# Altium schematic sheet ETX_Rev2_main.SchDoc(ETX_Rev2_main)
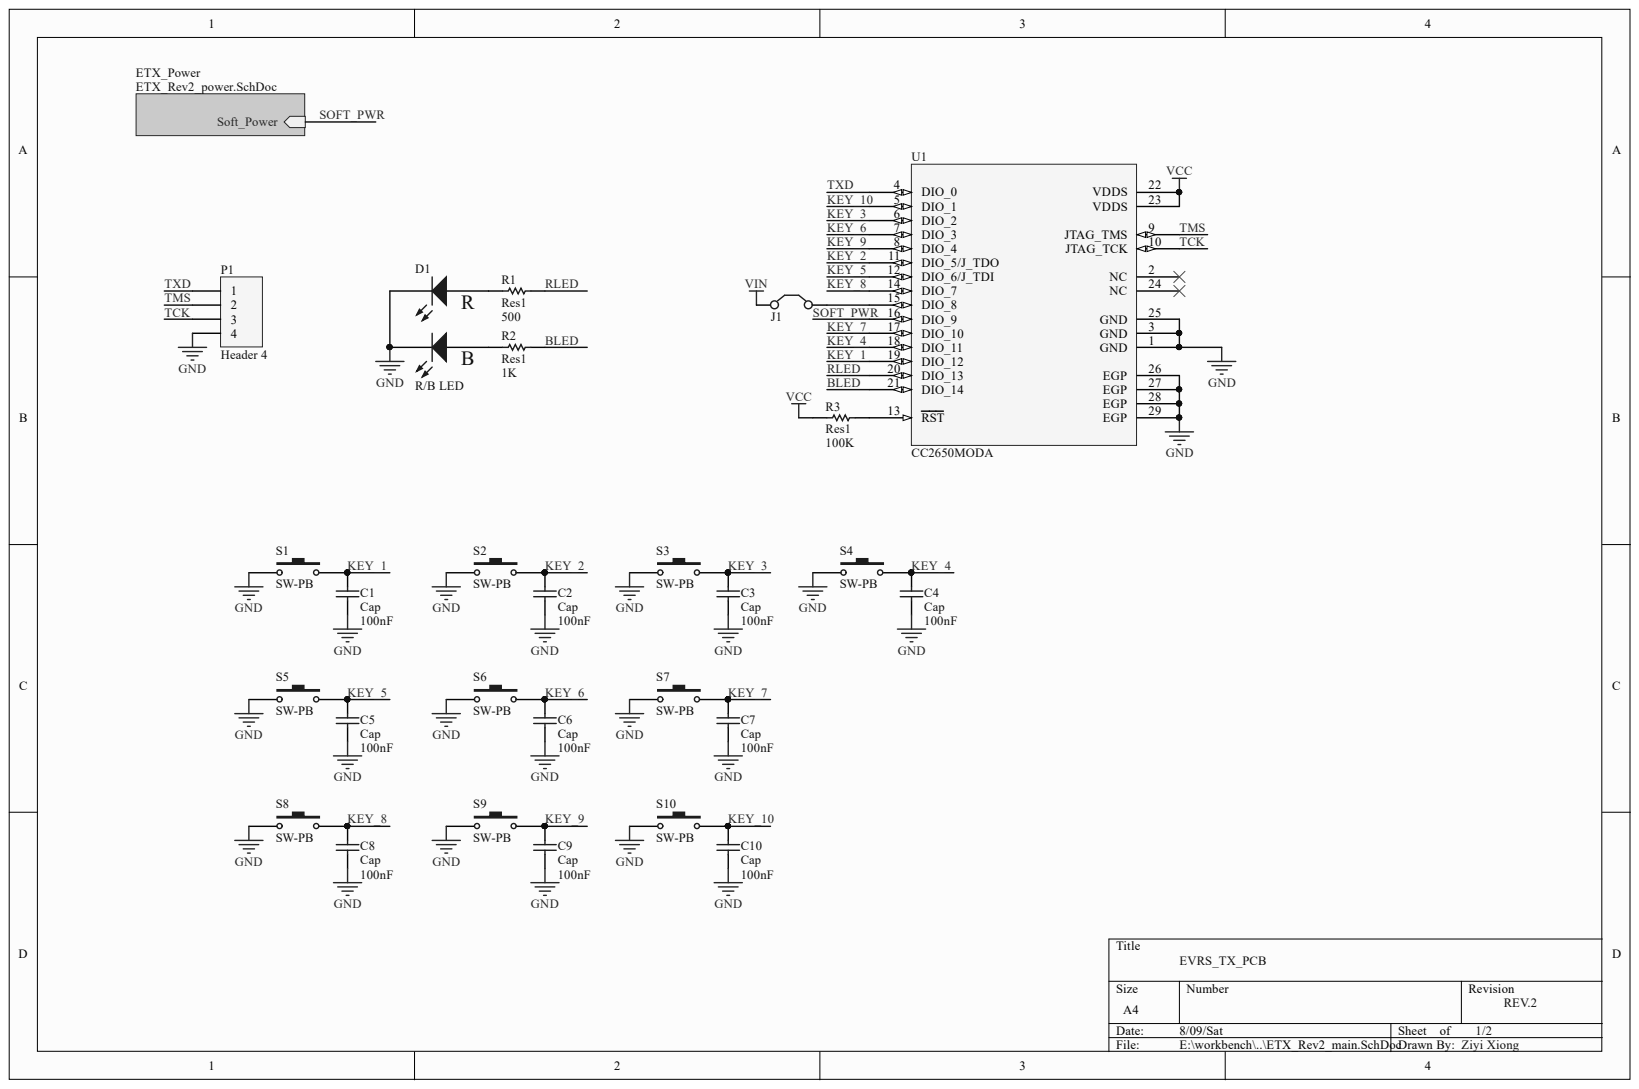
[diagram p0: ETX_Rev2_main.SchDoc]

## Parts
C1  Cap  value 100nF  [0805_NP]
C2  Cap  value 100nF  [0805_NP]
C3  Cap  value 100nF  [0805_NP]
C4  Cap  value 100nF  [0805_NP]
C5  Cap  value 100nF  [0805_NP]
C6  Cap  value 100nF  [0805_NP]
C7  Cap  value 100nF  [0805_NP]
C8  Cap  value 100nF  [0805_NP]
C9  Cap  value 100nF  [0805_NP]
C10  Cap  value 100nF  [0805_NP]
D1  R/B LED  [BILED]
J1  Jumper  [0805_NP]  (value not drawn)
P1  Header 4  [SOCKET 4POS]
R1  Res1  value 500  [0805_NP]
R2  Res1  value 1K  [0805_NP]
R3  Res1  value 100K  [0805_NP]
S1  SW-PB  [SWPB_large]
S2  SW-PB  [SWPB_large]
S3  SW-PB  [SWPB_large]
S4  SW-PB  [SWPB_large]
S5  SW-PB  [SWPB_large]
S6  SW-PB  [SWPB_large]
S7  SW-PB  [SWPB_large]
S8  SW-PB  [SWPB_large]
S9  SW-PB  [SWPB_large]
S10  SW-PB  [SWPB_large]
U1  CC2650MODA  [MOH0029A]

## Nets
GND: C1.1 C2.1 C3.1 C4.1 C5.1 C6.1 C7.1 C8.1 C9.1 C10.1 D1.1 D1.4 P1.4 S1.1 S2.1 S3.1 S4.1 S5.1 S6.1 S7.1 S8.1 S9.1 S10.1 U1.1(GND) U1.3(GND) U1.25(GND) U1.26(EGP) U1.27(EGP) U1.28(EGP) U1.29(EGP)
VCC: R3.1 U1.22(VDDS) U1.23(VDDS)
VIN: J1.1
BLED: R2.2 U1.21(DIO_14)
KEY_1: C1.2 S1.2 U1.19(DIO_12)
KEY_2: C2.2 S2.2 U1.11(DIO_5/J_TDO)
KEY_3: C3.2 S3.2 U1.6(DIO_2)
KEY_4: C4.2 S4.2 U1.18(DIO_11)
KEY_5: C5.2 S5.2 U1.12(DIO_6/J_TDI)
KEY_6: C6.2 S6.2 U1.7(DIO_3)
KEY_7: C7.2 S7.2 U1.17(DIO_10)
KEY_8: C8.2 S8.2 U1.14(DIO_7)
KEY_9: C9.2 S9.2 U1.8(DIO_4)
KEY_10: C10.2 S10.2 U1.5(DIO_1)
RLED: R1.2 U1.20(DIO_13)
SOFT_PWR: U1.16(DIO_9)
TCK: P1.3 U1.10(JTAG_TCK)
TMS: P1.2 U1.9(JTAG_TMS)
TXD: P1.1 U1.4(DIO_0)
NetD1_2: D1.2 R2.1
NetD1_3: D1.3 R1.1
NetJ1_2: J1.2 U1.15(DIO_8)
NetR3_2: R3.2 U1.13(RST)
NetU1_2: U1.2(NC)
NetU1_24: U1.24(NC)

## Library data of the parts
C1-C10 = Cap: Capacitor; Component Kind: Standard; LatestRevisionDate: 17-Jul-2002; LatestRevisionNote: Re-released for DXP Platform.; Library Name: Miscellaneous Devices.IntLib; PackageDescription: Radial Cap, Thru-Hole; 2 Leads; 0.3 in Pin Spacing; PackageReference: RAD-0.3; Published: 8-Jun-2000; Simulation: CAP; Publisher: Altium Limited
D1 = Bi-Color LED R/B: Bi-Color LED with red and blue; Component Kind: Standard; Library Name: EVRS_lib.SchLib
J1 = Jumper-SMD: 0805 Jumper; Component Kind: Standard; Library Name: EVRS_lib.SchLib
P1 = Header 4: Header, 4-Pin; Component Kind: Standard; LatestRevisionDate: 17-Jul-2002; LatestRevisionNote: Re-released for DXP Platform.; Library Name: Miscellaneous Connectors.IntLib; Publisher: Altium Limited
R1 = Res1: Resistor; Value: 500; Component Kind: Standard; LatestRevisionDate: 17-Jul-2002; LatestRevisionNote: Re-released for DXP Platform.; Library Name: Miscellaneous Devices.IntLib; PackageDescription: Axial Device, Thru-Hole; 2 Leads; 0.3 in Pin Spacing; PackageReference: AXIAL-0.3; Published: 8-Jun-2000; Simulation: RESISTOR; Publisher: Altium Limited
R2 = Res1: Resistor; Value: 1K; Component Kind: Standard; LatestRevisionDate: 17-Jul-2002; LatestRevisionNote: Re-released for DXP Platform.; Library Name: Miscellaneous Devices.IntLib; PackageDescription: Axial Device, Thru-Hole; 2 Leads; 0.3 in Pin Spacing; PackageReference: AXIAL-0.3; Published: 8-Jun-2000; Simulation: RESISTOR; Publisher: Altium Limited
R3 = Res1: Resistor; Component Kind: Standard; LatestRevisionDate: 17-Jul-2002; LatestRevisionNote: Re-released for DXP Platform.; Library Name: Miscellaneous Devices.IntLib; PackageDescription: Axial Device, Thru-Hole; 2 Leads; 0.3 in Pin Spacing; PackageReference: AXIAL-0.3; Published: 8-Jun-2000; Simulation: RESISTOR; Publisher: Altium Limited
S1-S10 = SW-PB: Switch; Component Kind: Standard; LatestRevisionDate: 17-Jul-2002; LatestRevisionNote: Re-released for DXP Platform.; Library Name: Miscellaneous Devices.IntLib; PackageDescription: Switch; 2 Leads; PackageReference: SPST-2; Published: 24-Mar-1999; Publisher: Altium Limited
U1 = CC2650MODA: Component Kind: Standard; Library Name: EVRS_lib.SchLib
